# Altium schematic sheet SH_SOKET2_V1.0.SchDot
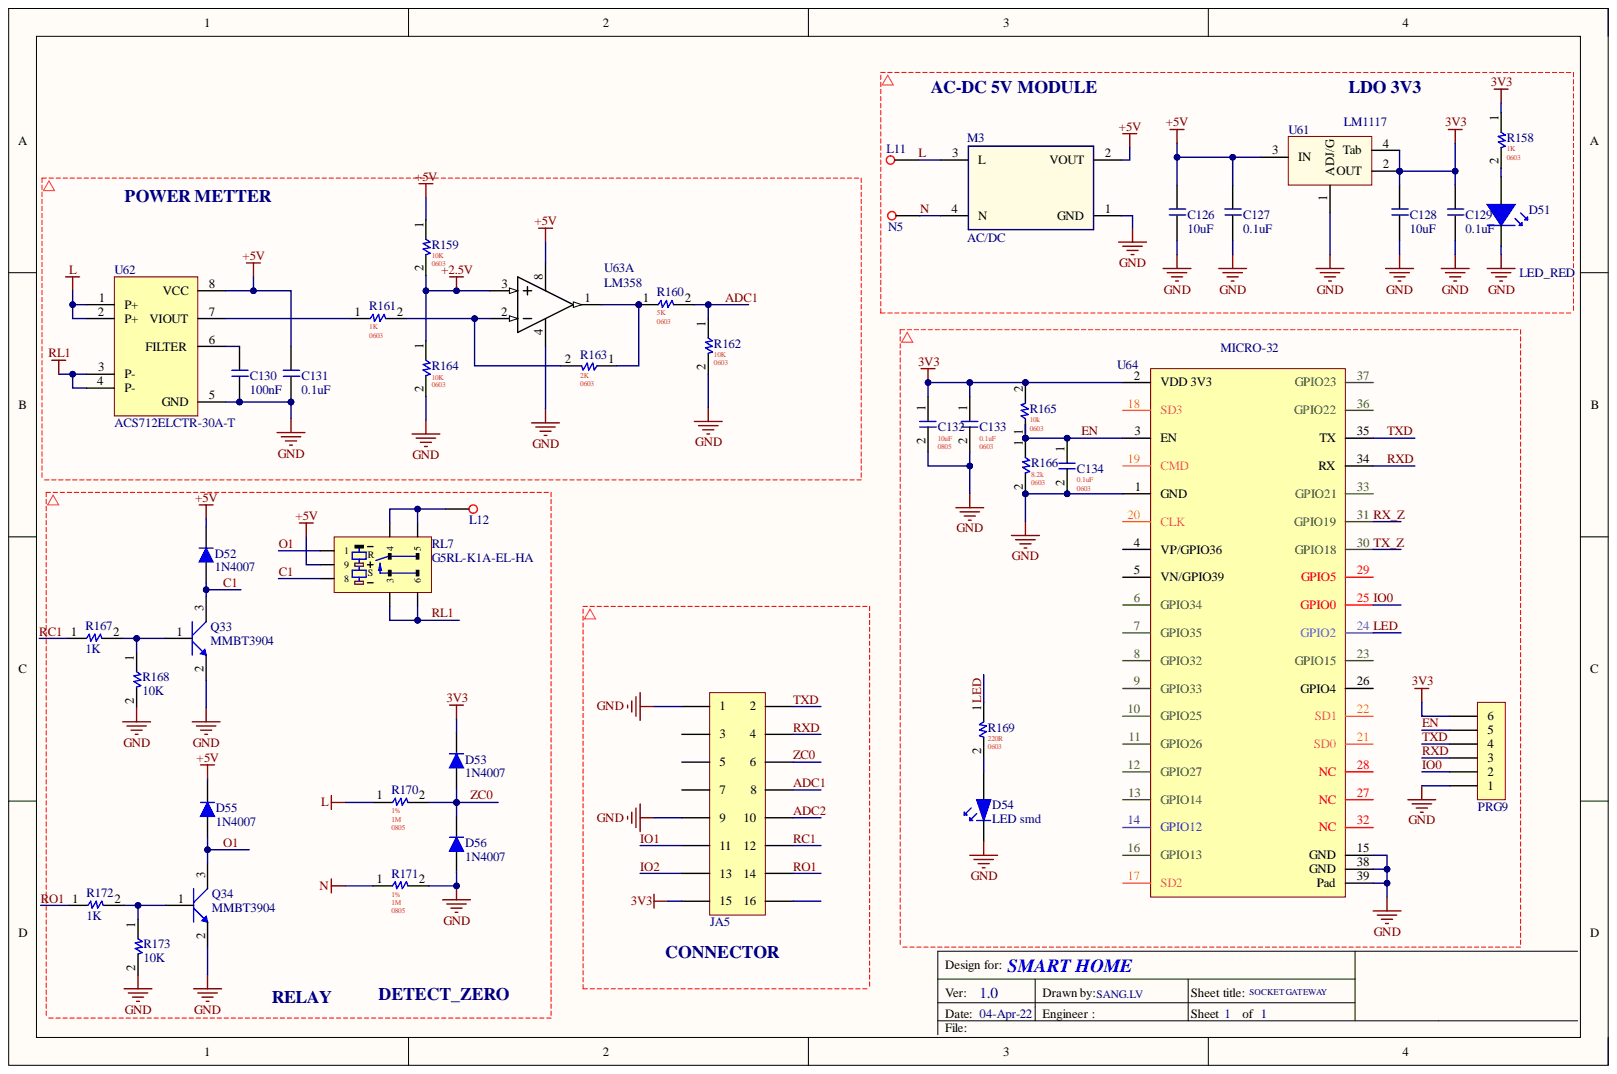
[diagram p0: SH_SOKET2_V1.0.SchDot]

## Parts
C126  10uF  [0805 C]
C127  0.1uF  [0603 C]
C128  10uF  [0805 C]
C129  0.1uF  [0603 C]
C130  100nF  [0603 C]
C131  0.1uF  [0603 C]
C132  10uF  FP: 0805  [0805 C]
C133  0.1uF  FP: 0603  [0603 C]
C134  0.1uF  FP: 0603  [0603 C]
D51  LED_RED  [LED0603_1608]
D52  1N4007  [SOD-323]
D53  1N4007  [SOD-323]
D54  LED smd  [LED0603_1608]
D55  1N4007  [SOD-323]
D56  1N4007  [SOD-323]
JA5  rao doi  [T]  (value not drawn)
L11  P1  [P1]  (value not drawn)
L12  P1  [P1]  (value not drawn)
M3  AC/DC  [AC-DC 5V K4H24]
N5  P1  [P1]  (value not drawn)
PRG9  P6  [P6 - 1.27MM]  (value not drawn)
Q33  MMBT3904  [SOT 23-3]
Q34  MMBT3904  [SOT 23-3]
R158  value 1K  FP: 0603  [0603 R]  (hidden comment: 10K)
R159  10K  FP: 0603  [0603 R]
R160  5K  FP: 0603  [0603 R]
R161  1K  FP: 0603  [0603 R]
R162  10K  FP: 0603  [0603 R]
R163  2K  FP: 0603  [0603 R]
R164  10K  FP: 0603  [0603 R]
R165  10k  FP: 0603  [0603 R]
R166  8.2k  FP: 0603  [0603 R]
R167  1K  [0603 R]
R168  10K  [0603 R]
R169  value 220R  FP: 0603  [0603 R]  (hidden comment: 10k)
R170  value 1M  FP: 0805  VOLTAGE + TOLE: 1%  [0805 R]  (hidden comment: 1K)
R171  value 1M  FP: 0805  VOLTAGE + TOLE: 1%  [0805 R]  (hidden comment: 1K)
R172  1K  [0603 R]
R173  10K  [0603 R]
RL7  G5RL-K1A-EL-HA  [RELAY LATCHING]
U61  LM1117  [SOT23-4]
U62  ACS712ELCTR-30A-T  [SOIC 8]
U63  LM358  [SOIC 8]  (part A)
U64  MICRO-32  [ESP MICRO 32]

## Nets
3V3: C128.2 C129.2 C132.1 C133.1 D53.2 JA5.15 PRG9.6 R158.1 R165.2 U61.2(OUT) U61.4(Tab) U64.2(VDD_3V3)
+2.5V: R159.2 R164.1 U63.3
+5V: C126.2 C127.2 C131.2 D52.2 D55.2 M3.2(VOUT) R159.1 RL7.9 U61.3(IN) U62.8(VCC) U63.8
GND: C126.1 C127.1 C128.1 C129.1 C130.1 C131.1 C132.2 C133.2 C134.2 D51.2 D54.2 D56.1 JA5.1 JA5.9 M3.1(GND) PRG9.1 Q33.2 Q34.2 R162.2 R164.2 R166.2 R168.2 R171.2 R173.2 U61.1(ADJ/G) U62.5(GND) U63.4 U64.1(GND) U64.15(GND) U64.38(GND) U64.39(Pad)
ADC1: JA5.8 R160.2 R162.1
ADC2: JA5.10
C1: D52.1 Q33.3 RL7.8
EN: C134.1 PRG9.5 R165.1 R166.1 U64.3(EN)
IO0: PRG9.2 U64.25(GPIO0)
IO1: JA5.11
IO2: JA5.13
L: L11.1 M3.3(L) R170.1 U62.1(P+) U62.2(P+)
LED: R169.1 U64.24(GPIO2)
N: M3.4(N) N5.1 R171.1
O1: D55.1 Q34.3 RL7.1
RC1: JA5.12 R167.1
RL1: RL7.3 RL7.6 U62.3(P-) U62.4(P-)
RO1: JA5.14 R172.1
RX_Z: U64.31(GPIO19)
RXD: JA5.4 PRG9.3 U64.34(RX)
TX_Z: U64.30(GPIO18)
TXD: JA5.2 PRG9.4 U64.35(TX)
ZC0: D53.1 D56.2 JA5.6 R170.2
NetC130_2: C130.2 U62.6(FILTER)
NetD51_1: D51.1 R158.2
NetD54_1: D54.1 R169.2
NetL12_1: L12.1 RL7.4 RL7.5
NetQ33_1: Q33.1 R167.2 R168.1
NetQ34_1: Q34.1 R172.2 R173.1
NetR160_1: R160.1 R163.1 U63.1
NetR161_1: R161.1 U62.7(VIOUT)
NetR161_2: R161.2 R163.2 U63.2

Not connected: JA5 3 5 7 16; U64 4(VP/GPIO36) 5(VN/GPIO39) 6(GPIO34) 7(GPIO35) 8(GPIO32) 9(GPIO33) 10(GPIO25) 11(GPIO26) 12(GPIO27) 13(GPIO14) 14(GPIO12) 16(GPIO13) 17(SD2) 18(SD3) 19(CMD) 20(CLK) 21(SD0) 22(SD1) 23(GPIO15) 26(GPIO4) 27(NC) 28(NC) 29(GPIO5) 32(NC) 33(GPIO21) 36(GPIO22) 37(GPIO23)

## Library data of the parts
C126-C131 = CAP NOP: Component Kind: Standard; Library Name: Kienlib.SchLib
C132 = 0805 10uF CER: CAP CER 10uF 50V 10% 0805 SMD; VOLTAGE + TOLE: 50V 10%; Component Kind: Standard; ComponentLink1Description: New link; DATE: 04-Mar-21; Library Name: Capacitor.SchLib; PACKAGE: SMD; VALUE: 10uF; CREATOR: MMUOP
C133 C134 = 0603 0.1uF CER: CAP CER 0.1uF 50V 10% 0603 SMD; VOLTAGE + TOLE: 50V 10%; Component Kind: Standard; ComponentLink1Description: New link; DATE: 04-Mar-21; Library Name: Capacitor.SchLib; PACKAGE: SMD; VALUE: 0.1uF; CREATOR: MMUOP
D51 = LED smd: Component Kind: Standard; Library Name: Kienlib.SchLib
D52 D53 D55 D56 = Diode schottky: Component Kind: Standard; Library Name: Kienlib.SchLib
JA5 = P2x8: Component Kind: Standard; Library Name: Kienlib.SchLib
Q33 Q34 = TRANS NPN: Component Kind: Standard; Library Name: Kienlib.SchLib
R158 = 0603 10k 1%: 100R 1% 0603 SMD; PN: C98220; Component Kind: Standard; ComponentLink1Description: New link; DATE: 04-Mar-21; Library Name: Res.SchLib; PACKAGE: SMD; VOLTAGE + TOLE: 1%; CREATOR: MMUOP
R159 R164 = 0603 100k 1%: 100k 1% 0603 SMD; Component Kind: Standard; ComponentLink1Description: New link; DATE: 04-Mar-21; Library Name: Res.SchLib; PACKAGE: SMD; VALUE: 10K; VOLTAGE + TOLE: 1%; CREATOR: MMUOP
R160 = 0603 100k 1%: 5K 1% 0603 SMD; Component Kind: Standard; ComponentLink1Description: New link; DATE: 04-Mar-21; Library Name: Res.SchLib; PACKAGE: SMD; VALUE: 5K; VOLTAGE + TOLE: 1%; CREATOR: MMUOP
R161 = 0603 100k 1%: 1K 1% 0603 SMD; Component Kind: Standard; ComponentLink1Description: New link; DATE: 04-Mar-21; Library Name: Res.SchLib; PACKAGE: SMD; VALUE: 1K; VOLTAGE + TOLE: 1%; CREATOR: MMUOP
R162 = 0603 100k 1%: 10K 1% 0603 SMD; Component Kind: Standard; ComponentLink1Description: New link; DATE: 04-Mar-21; Library Name: Res.SchLib; PACKAGE: SMD; VALUE: 10K; VOLTAGE + TOLE: 1%; CREATOR: MMUOP
R163 = 0603 100k 1%: 2K 1% 0603 SMD; Component Kind: Standard; ComponentLink1Description: New link; DATE: 04-Mar-21; Library Name: Res.SchLib; PACKAGE: SMD; VALUE: 2K; VOLTAGE + TOLE: 1%; CREATOR: MMUOP
R165 = 0603 10k 1%: 10k 1% 0603 SMD; PN: C98220; Component Kind: Standard; ComponentLink1Description: New link; DATE: 04-Mar-21; Library Name: Res.SchLib; PACKAGE: SMD; VALUE: 10k; VOLTAGE + TOLE: 1%; CREATOR: MMUOP
R166 = 0603 8.2k 1%: 8.2k 1% 0603 SMD; Component Kind: Standard; ComponentLink1Description: New link; DATE: 04-Mar-21; Library Name: Res.SchLib; PACKAGE: SMD; VALUE: 8.2k; VOLTAGE + TOLE: 1%; CREATOR: MMUOP
R167 R168 R172 R173 = Res SMD: Component Kind: Standard; Library Name: Kienlib.SchLib
R169 = 0603 10k 1%: 220R 1% 0603 SMD; PN: C98220; Component Kind: Standard; ComponentLink1Description: New link; DATE: 04-Mar-21; Library Name: Res.SchLib; PACKAGE: SMD; VOLTAGE + TOLE: 1%; CREATOR: MMUOP
R170 R171 = RES 0805 1K 1%: 1M 1% 0805 SMD; Component Kind: Standard; ComponentLink1Description: New link; DATE: 04-Mar-21; Library Name: Res.SchLib; PACKAGE: SMD; CREATOR: MMUOP
RL7 = RELAY 1 coil G5RL-K1A-EL-HA: Component Kind: Standard; Library Name: Kienlib.SchLib
U61 = LM1117: 3v3; Value: LM317; Component Kind: Standard; Library Name: VioletofSun.SchLib
U62 = ACS71240: ACS712ELCTR-20A  SOIC 8; Component Kind: Standard; ComponentLink1Description: New link; ComponentLink1URL: https://banlinhkiendientu.vn/acs712t/; Library Name: Kienlib.SchLib
U63 = OPAMP: Component Kind: Standard; Library Name: Kienlib.SchLib
U64 = ESP MICRO-32_FIX: Component Kind: Standard; Description: MICRO-32; Library Name: Kienlib.SchLib
D54 = LED smd: Component Kind: Standard; Library Name: Kienlib.SchLib
L11 L12 N5 = P1: Component Kind: Standard; Library Name: Kienlib.SchLib
M3 = AC/DC: Component Kind: Standard; Library Name: Kienlib.SchLib
PRG9 = P6: Component Kind: Standard; Library Name: Kienlib.SchLib
